# Altium schematic sheet BatteryCharger.SchDoc
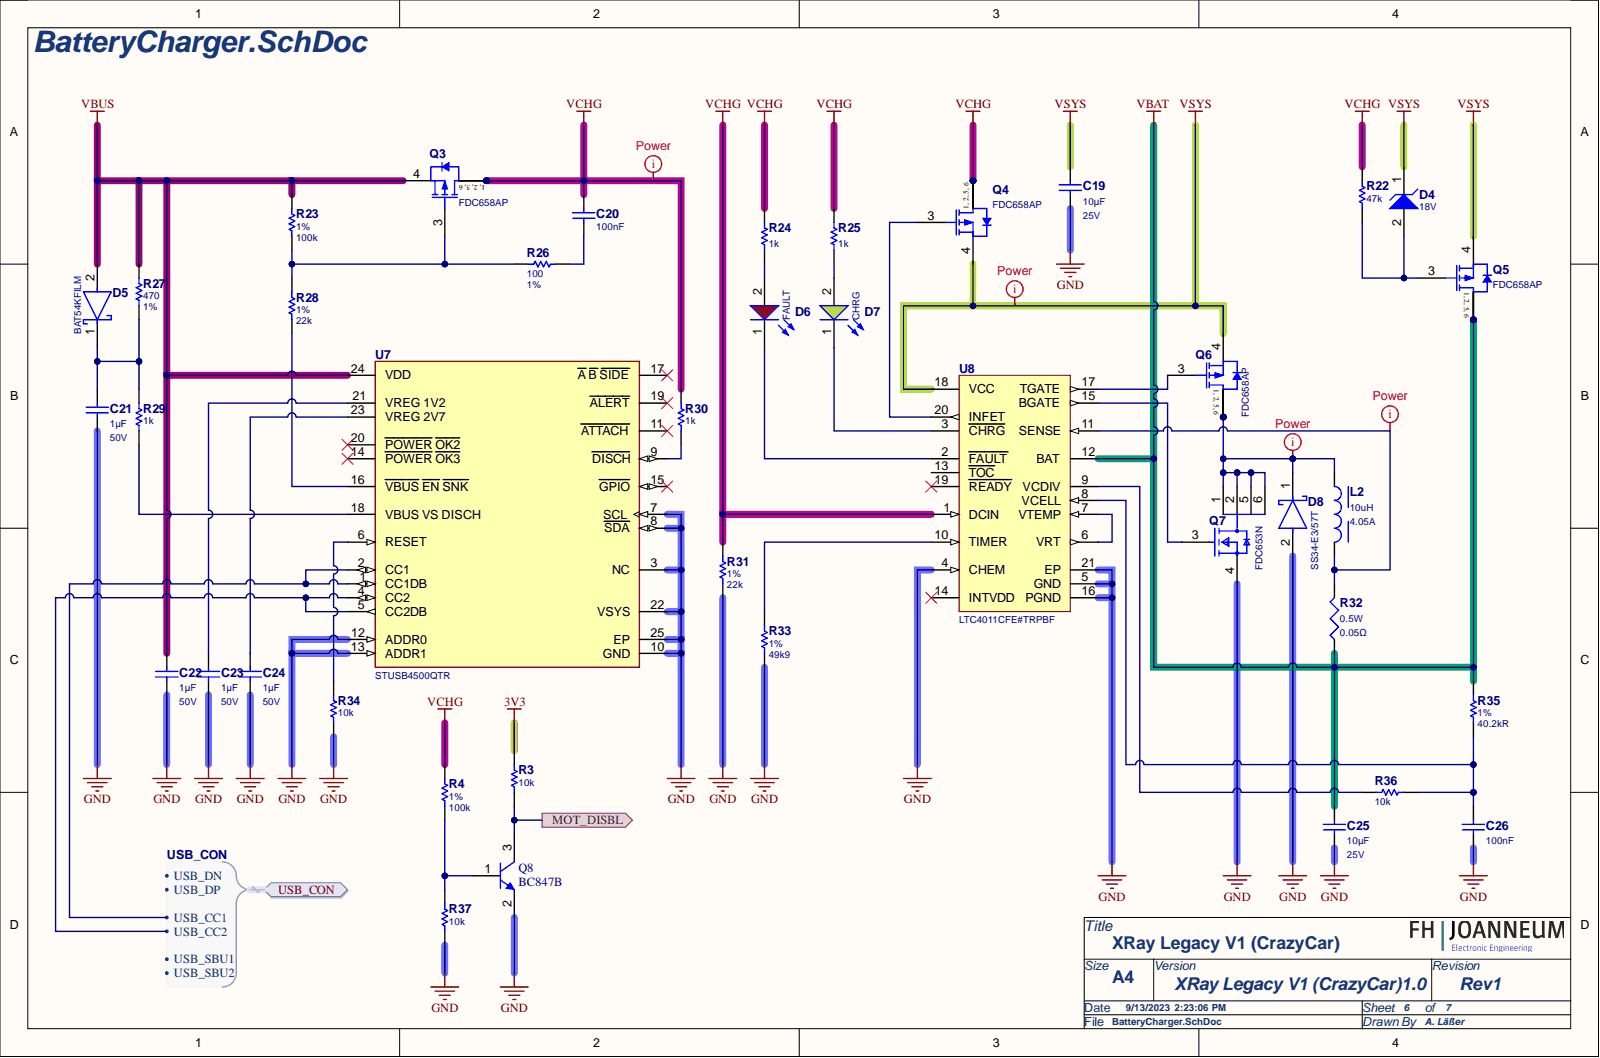
[diagram p0: BatteryCharger.SchDoc]

## Parts
C19  10µF  Rated Voltage (DC): 25V  [WCAP-CSGP_1206_L3.2W1.6H1.6]
C20  100nF  [WCAP-CSGP_0805_L2W1.25H0.8_Reflow]
C21  1µF  Rated Voltage (DC): 50V  [WCAP-CSGP_0805_L2W1.25H1.25]
C22  1µF  Rated Voltage (DC): 50V  [WCAP-CSGP_0805_L2W1.25H1.25]
C23  1µF  Rated Voltage (DC): 50V  [WCAP-CSGP_0805_L2W1.25H1.25]
C24  1µF  Rated Voltage (DC): 50V  [WCAP-CSGP_0805_L2W1.25H1.25]
C25  10µF  Rated Voltage (DC): 25V  [WCAP-CSGP_1206_L3.2W1.6H1.6]
C26  100nF  [WCAP-CSGP_0805_L2W1.25H0.8_Reflow]
D4  VZ Typ (V): 18V  [ONSC-SOD-123-2-425-04_V]  (hidden comment: MMSZ5248BT1G)
D5  BAT54KFILM  [FP-SOD-523-MFG]
D6  FAULT  [WL-SMCC_0603_L1.6W0.8H0.2]
D7  CHRG  [WL-SMCC_0603_L1.6W0.8H0.2]
D8  SS34-E3/57T  [FP-95402-MFG]
L2  10uH, 4.05A  Inductance: 10uH  Performance Rated Current: 4.05A  [WE-LHMI_7050]
Q3  FDC658AP  [FAIR-SOT23-6_V]
Q4  FDC658AP  [FAIR-SOT23-6_V]
Q5  FDC658AP  [FAIR-SOT23-6_V]
Q6  FDC658AP  [FAIR-SOT23-6_V]
Q7  FDC653N  [FP-419BL-MFG]
Q8  BC847B  [INF-SOT23_M]
R3  Resistance: 10k  [RESC2013X60X35ML10T20]  (hidden comment: RC0805FR-0710KL)
R4  Resistance: 100k  Tolerance: 1%  [RESC2013X60X35ML10T20]  (hidden comment: RC0805FR-13100KL)
R22  Resistance: 47k  [RESC2013X60X35NL10T20]  (hidden comment: RC0805FR-0747KL)
R23  Resistance: 100k  Tolerance: 1%  [RESC2013X60X35ML10T20]  (hidden comment: RC0805FR-13100KL)
R24  Resistance: 1k  [RESC2013X60X35ML10T20]  (hidden comment: RC0805FR-071KL)
R25  Resistance: 1k  [RESC2013X60X35ML10T20]  (hidden comment: RC0805FR-071KL)
R26  Tolerance: 1%  [RESC2013X60X35ML10T20]  (hidden comment: RC0805FR-07100RL)
R27  Tolerance: 1%  [RESC2013X60X35ML10T20]  (hidden comment: RC0805FR-07470RL)
R28  Resistance: 22k  Tolerance: 1%  [RESC2013X60X35ML10T20]  (hidden comment: RC0805FR-1022KL)
R29  Resistance: 1k  [RESC2013X60X35ML10T20]  (hidden comment: RC0805FR-071KL)
R30  Resistance: 1k  [RESC2013X60X35ML10T20]  (hidden comment: RC0805FR-071KL)
R31  Resistance: 22k  Tolerance: 1%  [RESC2013X60X35ML10T20]  (hidden comment: RC0805FR-1022KL)
R32  0.05Ω  Rated Power: 0.5W  [WRIS-KSKE_1206_L3.1W1.6H0.6]
R33  Resistance: 49k9  Tolerance: 1%  [RESC2013X60X35LL10T20]  (hidden comment: RC0805FR-1349K9L)
R34  Resistance: 10k  [RESC2013X60X35ML10T20]  (hidden comment: RC0805FR-0710KL)
R35  Resistance: 40.2kR  Tolerance: 1%  [RESC2013X60X35NL10T20]  (hidden comment: RC0805FR-07402KL)
R36  Resistance: 10k  [RESC2013X60X35ML10T20]  (hidden comment: RC0805FR-0710KL)
R37  Resistance: 10k  [RESC2013X60X35ML10T20]  (hidden comment: RC0805FR-0710KL)
U7  STUSB4500QTR  [FP-QFN-24-EP_4x4-MFG]
U8  LTC4011CFE#TRPBF  [LT-FE-20-EPV-CB_M]

## Ports
MOT_DISBL, USB_CON, USB_CON.USB_CC1, USB_CON.USB_CC2, USB_CON.USB_DN, USB_CON.USB_DP, USB_CON.USB_SBU1, USB_CON.USB_SBU2

## Nets
3V3: R3.1
GND: C19.2 C21.2 C22.2 C23.2 C24.2 C25.2 C26.1 D8.2 Q7.4 Q8.2 R31.2 R33.2 R34.1 R37.2 U7.3(NC) U7.7(SCL) U7.8(SDA) U7.10(GND) U7.12(ADDR0) U7.13(ADDR1) U7.22(VSYS) U7.25(EP) U8.4(CHEM) U8.5(GND) U8.16(PGND) U8.21(EP)
VBAT: C25.1 Q5.1 Q5.2 Q5.5 Q5.6 R32.1 R35.2 U8.12(BAT)
VBUS: C22.1 D5.2 Q3.4 R23.1 R27.1 U7.24(VDD)
VCHG: C20.2 Q3.1 Q3.2 Q3.5 Q3.6 Q4.1 Q4.2 Q4.5 Q4.6 R4.1 R22.1 R24.1 R25.1 R30.2 R31.1 U8.1(DCIN)
VSYS: C19.1 D4.1 Q4.4 Q5.4 Q6.4 U8.18(VCC)
MOT_DISBL: Q8.3 R3.2 ; port MOT_DISBL
NetC20_1: C20.1 R26.2
NetC21_1: C21.1 D5.1 R27.2 R29.2
NetC23_1: C23.1 U7.21(VREG_1V2)
NetC24_1: C24.1 U7.23(VREG_2V7)
NetC26_2: C26.2 R35.1 R36.1 U8.8(VCELL)
NetD4_2: D4.2 Q5.3 R22.2
NetD6_1: D6.1 U8.2(FAULT)
NetD6_2: D6.2 R24.2
NetD7_1: D7.1 U8.3(CHRG)
NetD7_2: D7.2 R25.2
NetD8_1: D8.1 L2.1 Q6.1 Q6.2 Q6.5 Q6.6 Q7.1 Q7.2 Q7.5 Q7.6
NetL2_2: L2.2 R32.2 U8.11(SENSE)
NetQ3_3: Q3.3 R23.2 R26.1 R28.1
NetQ4_3: Q4.3 U8.20(INFET)
NetQ6_3: Q6.3 U8.17(TGATE)
NetQ7_3: Q7.3 U8.15(BGATE)
NetQ8_1: Q8.1 R4.2 R37.1
NetR28_2: R28.2 U7.16(VBUS_EN_SNK)
NetR29_1: R29.1 U7.18(VBUS_VS_DISCH)
NetR30_1: R30.1 U7.9(DISCH)
NetR33_1: R33.1 U8.10(TIMER)
NetR34_2: R34.2 U7.6(RESET)
NetR36_2: R36.2 U8.9(VCDIV)
NetU7_1: U7.1(CC1DB) U7.2(CC1) ; port USB_CON
NetU7_4: U7.4(CC2) U7.5(CC2DB) ; port USB_CON
NetU8_6: U8.6(VRT) U8.7(VTEMP)
N00001: ; port USB_CON
N00002: ; port USB_CON
N00003: ; port USB_CON
N00004: ; port USB_CON

Not connected: U7 11(ATTACH) 14(POWER_OK3) 15(GPIO) 17(A_B_SIDE) 19(ALERT) 20(POWER_OK2); U8 13(TOC) 14(INTVDD) 19(READY)

## Library data of the parts
C19 C25 = 885012108021: WCAP-CSGP Multilayer Ceramic Chip Capacitor, General Purpose, size 1206, X5R, 10µF, 25VDC; Manufacturer: Wurth Elektronik; Capacitance Tolerance: +/-20%; Case/Size Code: 1206; Dissipation Factor: 10%; Insulation Resistance: 0.01GΩ; Technical Reference: X5R1206106M025DFCT10000; Capacitance: 10µF; Category: Multilayer Ceramic Chip Capacitors; Ceramic Type: X5R; Component Kind: Standard; ComponentLink1Description: Website Link; ComponentLink1URL: https://www.we-online.com/redexpert/article/885012108021?ad; ComponentLink2Description: Datasheet Link; ComponentLink2URL: https://www.we-online.com/redexpert/spec/885012108021?ad; Height: 1.6mm; Length: 3.2mm; Library Name: Altium_WCAP-CSGP (rev22e).IntLib; Manufacturer Part Number: 885012108021; Match Code: WCAP-CSGP; Mount: Surface Mount; Operating Temperature Max: 85°C; Operating Temperature Min: -55°C; Packaging: Tape and Reel; Width: 1.6mm
C20 C26 = 885012207098: WCAP-CSGP Multilayer Ceramic Chip Capacitor, General Purpose, size 0805, X7R, 100nF, 50VDC; Manufacturer: Wurth Elektronik; Capacitance Tolerance: +/-10%; Case/Size Code: 0805; Dissipation Factor: 2.5%; Insulation Resistance: 5GΩ; Rated Voltage (DC): 50V; Technical Reference: X7R0805104K050DFCT10000; Capacitance: 100nF; Category: Multilayer Ceramic Chip Capacitors; Ceramic Type: X7R; Component Kind: Standard; ComponentLink1Description: Website Link; ComponentLink1URL: https://www.we-online.com/redexpert/article/885012207098?ad; ComponentLink2Description: Datasheet Link; ComponentLink2URL: https://www.we-online.com/redexpert/spec/885012207098?ad; Height: 0.8mm; Length: 2mm; Library Name: Altium_WCAP-CSGP (rev22e).IntLib; Manufacturer Part Number: 885012207098; Match Code: WCAP-CSGP; Mount: Surface Mount; Operating Temperature Max: 125°C; Operating Temperature Min: -55°C; Packaging: Tape and Reel; Width: 1.25mm
C21-C24 = 885012207103: WCAP-CSGP Multilayer Ceramic Chip Capacitor, General Purpose, size 0805, X7R, 1µF, 50VDC; Manufacturer: Wurth Elektronik; Capacitance Tolerance: +/-10%; Case/Size Code: 0805; Dissipation Factor: 10%; Insulation Resistance: 0.1GΩ; Technical Reference: X7R0805105K050DFCT10000; Capacitance: 1µF; Category: Multilayer Ceramic Chip Capacitors; Ceramic Type: X7R; Component Kind: Standard; ComponentLink1Description: Website Link; ComponentLink1URL: https://www.we-online.com/redexpert/article/885012207103?ad; ComponentLink2Description: Datasheet Link; ComponentLink2URL: https://www.we-online.com/redexpert/spec/885012207103?ad; Height: 1.25mm; Length: 2mm; Library Name: Altium_WCAP-CSGP (rev22e).IntLib; Manufacturer Part Number: 885012207103; Match Code: WCAP-CSGP; Mount: Surface Mount; Operating Temperature Max: 125°C; Operating Temperature Min: -55°C; Packaging: Tape and Reel; Width: 1.25mm
D4 = CMP-1060-00505-1: Zener Voltage Regulator, 500 mW, 2-Pin SOD-123, Pb-Free, Tape and Reel; Manufacturer: On Semiconductor; P Max (W): 0.5; Component Kind: Standard; ComponentLink1Description: Manufacturer URL; ComponentLink1URL: http://www.onsemi.com/; ComponentLink2Description: Datasheet; ComponentLink2URL: http://www.onsemi.com/pub_link/Collateral/MMSZ5221BT1-D.PDF; ComponentLink3Description: Package Specification; ComponentLink3URL: http://www.onsemi.com/pub_link/Collateral/425-04.PDF; DatasheetVersion: Rev. 12; Library Name: Altium Content Vault; Mounting Technology: Surface Mount; PackageDescription: 2-Pin SOD, Body 1.6 x 2.69 mm; PackageReference: SOD-123-2-425-04; PackageVersion: Rev. G, 10/2009; Packing: Tape and Reel; PartNumber: MMSZ5248BT1G; RoHS: Pb-Free
D5 = CMP-12187-000026-1: DIODE SCHOTTKY 40V 300MA SOD523; Manufacturer: STMicroelectronics; Capacitance: 7pF; Max Junction Temp: +150°C; Max Surge Forward Current: 1.25A; Peak Reverse Current: 1uA; Value: 7pF; Automotive: No; Category: Diode; Component Kind: Standard; ComponentLink2Description: Datasheet; ComponentLink2URL: https://www.st.com/content/ccc/resource/technical/document/datasheet/07/50/54/f2/0d/ba/4a/79/CD00001322.pdf/files/CD00001322.pdf/jcr:content/translations/en.CD00001322.pdf; Device Class L1: Discrete Semiconductors; Device Class L2: Diodes; Device Class L3: Schottky Diodes; Digikey Description: DIODE SCHOTTKY 40V 300MA SOD523; Forward Current: 300mA; Height: 0.7mm; Lead Free: Yes; Library Name: Altium Content Vault; Manufacturer Part Number: BAT54KFILM; Max Repetitive Reverse Voltage: 40V; Mouser Description: Schottky Diodes & Rectifiers schottky diode; Octopart: https://octopart.com/bat54kfilm-stmicroelectronics-39618022; Package: SOD-523; RoHS: TRUE; Temperature: -40°C to +150°C; Temperature Range High: +150°C; Temperature Range Low: -40°C
D6 = 150060RS73220: WL-SMCC Mono-color Chip LED Compact, size 0603, Red, 2V, 120°; Manufacturer: Wurth Elektronik; Case/Size Code: 0603; Chip Technology: AlInGaP; Dominant Wavelength: 624nm; Luminous Intensity: 90mcd; Peak Wavelength: 632nm; Category: Signal LEDs; Component Kind: Standard; ComponentLink1Description: Website Link; ComponentLink1URL: https://www.we-online.com/redexpert/article/150060RS73220?ad; ComponentLink2Description: Datasheet Link; ComponentLink2URL: https://www.we-online.com/redexpert/spec/150060RS73220?ad; Emitting Color: Red; Forward Voltage: 2V; Height: 0.2mm; Length: 1.6mm; Library Name: Altium_WL-SMCC (rev22b).IntLib; Manufacturer Part Number: 150060RS73220; Match Code: WL-SMCC; Mount: Surface Mount; Operating Temperature Max: 85°C; Operating Temperature Min: -40°C; Packaging: Tape and Reel; Simulation: 0603_150060RS73220; Viewing Angle: 120°; Width: 0.8mm
D7 = 150060YS73220: WL-SMCC Mono-color Chip LED Compact, size 0603, Yellow, 2V, 120°; Manufacturer: Wurth Elektronik; Case/Size Code: 0603; Chip Technology: AlInGaP; Dominant Wavelength: 589nm; Luminous Intensity: 100mcd; Peak Wavelength: 591nm; Category: Signal LEDs; Component Kind: Standard; ComponentLink1Description: Website Link; ComponentLink1URL: https://www.we-online.com/redexpert/article/150060YS73220?ad; ComponentLink2Description: Datasheet Link; ComponentLink2URL: https://www.we-online.com/redexpert/spec/150060YS73220?ad; Emitting Color: Yellow; Forward Voltage: 2V; Height: 0.2mm; Length: 1.6mm; Library Name: Altium_WL-SMCC (rev22b).IntLib; Manufacturer Part Number: 150060YS73220; Match Code: WL-SMCC; Mount: Surface Mount; Operating Temperature Max: 85°C; Operating Temperature Min: -40°C; Packaging: Tape and Reel; Simulation: 0603_150060YS73220; Viewing Angle: 120°; Width: 0.8mm
D8 = CMP-02539-000012-1: DIODE SCHOTTKY 40V 3A DO214AB; Manufacturer: Vishay; Max Junction Temp: +150°C; Max Surge Forward Current: 100A; Peak Reverse Current: 0.5mA; Standoff Height: 0mm; Automotive: No; Category: Diode; Component Kind: Standard; ComponentLink2Description: Datasheet; ComponentLink2URL: http://www.vishay.com/docs/88751/ss32.pdf; Device Class L1: Discrete Semiconductors; Device Class L2: Diodes; Device Class L3: Schottky Diodes; Digikey Description: DIODE SCHOTTKY 40V 3A DO214AB; Footprint Url: http://www.vishay.com/docs/95402/smc.pdf; Forward Current: 3A; Height: 2.62mm; Lead Free: Yes; Library Name: Altium Content Vault; Manufacturer Part Number: SS34-E3/57T; Max Repetitive Reverse Voltage: 40V; Mouser Description: Schottky Diodes & Rectifiers 3.0 Amp 40 Volt; Octopart: https://octopart.com/ss34-e3%2F57t-vishay-39552907; Package: 95402; RoHS: TRUE; Temperature: -55°C to +150°C; Temperature Range High: +150°C; Temperature Range Low: -55°C
L2 = 74437349100: WE-LHMI SMT Power Inductor, size 7050, 10uH, 4.05A, 69mOhm; Manufacturer: Wurth Elektronik; Case/Size Code: 7050; DC Resistance: 65mOhm; Saturation Current @ 10%: 5.6A; Saturation Current @ 30%: 10.5A; Self Resonant Frequency: 12MHz; Category: Power Inductors; Component Kind: Standard; ComponentLink1Description: Website Link; ComponentLink1URL: https://www.we-online.com/redexpert/article/74437349100?ad; ComponentLink2Description: Datasheet Link; ComponentLink2URL: https://www.we-online.com/redexpert/spec/74437349100?ad; DC Resistance Max: 69mOhm; Height: 4.8mm; Length: 6.6mm; Library Name: WE-LHMI (rev23a).IntLib; Manufacturer Part Number: 74437349100; Match Code: WE-LHMI; Mount: Surface Mount; Operating Temperature Max: 125°C; Operating Temperature Min: -40°C; Packaging: Tape and Reel; Simulation: 7050_74437349100_10u; Width: 6.6mm
Q3-Q6 = CMP-2000-06244-1: Single P-Channel Logic Level PowerTrench(R) MOSFET, -30 V, -4 A, -55 to 150 degC, 6-Pin SOT23, RoHS, Tape and Reel; Manufacturer: Fairchild Semiconductor; Drain-Source On Resistance-Max: 50mO; Drain-to-Source Voltage [Vdss]: 30V; Package Version: Rev. 5, 05/2015; Qg Gate Charge: 8.1nC; Rated Power Dissipation: 0.8W; Channel Type: P-Channel; Component Kind: Standard; Datasheet URL: https://datasheet.ciiva.com/15137/getdatasheetpartid-92299-15137737.pdf; Datasheet Version: Rev. B1, 11/2011; Library Name: Altium Content Vault; Manufacturer URL: https://www.fairchildsemi.com/; Mounting Technology: SurfaceMount; Package Description: 6-Pin Small Outline Transistor, Body 2.9 x 1.6 mm, Pitch 0.95 mm; Package Reference: SOT23-6; Package URL: https://www.fairchildsemi.com/package-drawings/MA/MA06A.pdf
Q7 = CMP-07173-000050-1: MOSFET N-CH 30V 5A SSOT-6; Manufacturer: ON Semiconductor; Depletion Mode: False; Drain To Source Resistance: 0.027Ω; Gate Charge At Vgs: 12nC @ 10V; Gate To Source Voltage: 20V; Input Capacitace At Vds: 350pF @ 15V; Max Forward Diode Voltage: 1.2V; Max Junction Temp: +150°C; Number Of Channels: 1; Power Dissipation: 1.6W; Pulse Drain Current: 15A; Rthja Max: 78°C/W; Standoff Height: 0mm; Threshold Vgs Max: 2V; Threshold Vgs Min: 1V; Transconductance: 6.2S; Turn Off Delay Time: 13ns; Turn On Delay Time: 7.5ns; Typ Forward Diode Voltage: 0.75V; Automotive: No; Category: Trans; Component Kind: Standard; ComponentLink2Description: Datasheet; ComponentLink2URL: https://www.onsemi.com/pub/Collateral/FDC653N-D.pdf; Continuous Drain Current: 5A; Device Class L1: Discrete Semiconductors; Device Class L2: Transistors; Device Class L3: MOSFETs; Digikey Description: MOSFET N-CH 30V 5A SSOT-6; Drain To Source Breakdown Voltage: 30V; Drain To Source Voltage: 30V; Footprint Url: https://www.onsemi.com/pub/Collateral/419BL.PDF; Height: 1.1mm; Ipc Land Pattern Name: SOT95P280X100-6; Lead Free: Yes; Library Name: Altium Content Vault; Manufacturer Part Number: FDC653N; Mouser Description: Supplied as a Tape, FDC653N N-Channel MOSFET, 5 A, 30 V, 6-Pin SOT-23 ON Semiconductor; Number Of N Channels: 1; Octopart: https://octopart.com/fdc653n-on+semiconductor-84325763; Package: 419BL; RoHS: TRUE; Temperature: -55°C to +150°C; Temperature Range High: +150°C; Temperature Range Low: -55°C
Q8 = CMP-1177-00042-1: NPN Silicon AF Transistor, 45 V VCEO, 100 mA IC, SOT23, Reel, Green; Manufacturer: Infineon; fT (typ): 250Â MHz; Green: TRUE; IBM (max): 200Â mA; IC (max): 100Â mA; ICBO (max): 15Â nA; Ptot (max): 330Â mW; VCBO (max): 50Â V; VCEO (max): 45Â V; VEBO (max): 6Â V; Component Kind: Standard; ComponentLink1Description: Manufacturer URL; ComponentLink1URL: http://www.infineon.com/; ComponentLink2Description: Datasheet; ComponentLink2URL: http://www.infineon.com/dgdl/bc847series_bc848series_bc849series_bc850series.pdf?folderId=db3a304314dca389011541d30fa21656&fileId=db3a304314dca389011541d4630a1657; ComponentLink3Description: Package Specification; ComponentLink3URL: http://www.infineon.com/dgdl/SOT23-Package_Overview.pdf?folderId=db3a30431936bc4b0119532290053c66&fileId=db3a30431936bc4b0119533468123c85; ICM (max): 200Â mA; Library Name: Altium Content Vault; Mounting Technology: Surface Mount; PackageDescription: 3-Pin Small Outline Transistor Package, 1.3 x 2.9 mm Body, 0.95 mm Pitch; PackageReference: SOT23; PackageVersion: Apr-08; Packing: Reel; PartNumber: BC847B; Polarity: NPN
R3 R34 R36 R37 = CMP-1659-00013-4: Chip Resistor, 10 KOhm, +/- 1%, 0.125 W, -55 to 155 degC, 0805 (2012 Metric), RoHS, Tape and Reel; Manufacturer: Yageo; Additional Feature: LASER TRIMMABLE; Package Height: 0.5mm; Package Length: 2mm; Package Width: 1.25mm; Rated Power Dissipation (P): 125mW; Rated Temperature: 70°C; Temperature Coefficient: 100ppm/°C; Voltage Rating: 150 V; Working Voltage: 150V; Category: Chip SMD Resistors; Component Kind: Standard; ComponentLink1Description: Manufacturer URL; ComponentLink1URL: http://www.yageo.com/; ComponentLink2Description: Datasheet; ComponentLink2URL: http://www.yageo.com/documents/recent/PYu-RC_Group_51_RoHS_L_04.pdf; ComponentLink3Description: https://datasheet.ciiva.com:443/pdfs/VipMasterIC/IC/YAGO/YAGO-S-A0008145938/YAGO-S-A0008145938-1.pdf?src-supplier=IHS+Markit; ComponentLink3URL: https://datasheet.ciiva.com:443/pdfs/VipMasterIC/IC/YAGO/YAGO-S-A0008145938/YAGO-S-A0008145938-1.pdf?src-supplier=IHS+Markit; ComponentLink4Description: https://datasheet.ciiva.com/11808/0900766b8106beab-11808151.pdf?src-supplier=RSComponents; ComponentLink4URL: https://datasheet.ciiva.com/11808/0900766b8106beab-11808151.pdf?src-supplier=RSComponents; ComponentLink5Description: https://datasheet.ciiva.com/46447/444164-46447563.pdf?src-supplier=Element14; ComponentLink5URL: https://datasheet.ciiva.com/46447/444164-46447563.pdf?src-supplier=Element14; ComponentLink6Description: https://datasheet.ciiva.com/2456/pyu-r-int-thick-7-2456973.pdf?src-supplier=Digi-Key; ComponentLink6URL: https://datasheet.ciiva.com/2456/pyu-r-int-thick-7-2456973.pdf?src-supplier=Digi-Key; ComponentLink7Description: https://datasheet.ciiva.com/2456/pyu-r-int-thick-7-2456974.pdf?src-supplier=Digi-Key; ComponentLink7URL: https://datasheet.ciiva.com/2456/pyu-r-int-thick-7-2456974.pdf?src-supplier=Digi-Key; ComponentLink8Description: https://datasheet.ciiva.com/2456/pyu-r-int-thick-7-2456972.pdf?src-supplier=Digi-Key; ComponentLink8URL: https://datasheet.ciiva.com/2456/pyu-r-int-thick-7-2456972.pdf?src-supplier=Digi-Key; ComponentLink9Description: https://datasheet.ciiva.com/12669/rc0805-12669688.pdf?src-supplier=Mouser; ComponentLink9URL: https://datasheet.ciiva.com/12669/rc0805-12669688.pdf?src-supplier=Mouser; ComponentLink10Description: https://datasheet.ciiva.com/16747/rc0805-16747087.pdf?src-supplier=Future+Electronics; ComponentLink10URL: https://datasheet.ciiva.com/16747/rc0805-16747087.pdf?src-supplier=Future+Electronics; Construction: Chip; DatasheetVersion: Rev. 4, 02/2016; Height: 0.5 mm; JESD-609 Code: e3; Length: 2 mm; Library Name: Altium Content Vault; Manufacturer Part Number: RC0805FR-0710KL; Max Operating Temperature: 155 degC; Min Operating Temperature: -55 degC; Mount: Surface Mount; Mounting Technology: Surface Mount; Number of Pins: 2; Package Quantity: 5000; Package Shape: Rectangular; Package Style: SMTMeter; PackageDescription: 2-Pin Surface Mounted Device, Body 2 x 1.25 mm; PackageReference: 0805; Packaging: Tape and Reel; Pins: 2; Pricing 1: 5000=0.00700 (USD); REACH SVHC: No SVHC; Resistor Type: FIXED RESISTOR; RoHS: Yes; RoHS Compliant: Yes; RoHSCompliant: true; Size Code: 0805; Stock 1: 435000; Supplier 1: RSComponents; Supplier Part Number 1: 6185163; Technology: METAL GLAZE/THICK FILM; Terminal Finish: Nickel; Termination: Wraparound; Tolerance: 1%
R4 = CMP-2001-01326-1: Manufacturer: Yageo; Additional Feature: LASER TRIMMABLE; Package Height: 0.5mm; Package Length: 2mm; Package Width: 1.25mm; Rated Power Dissipation (P): 125mW; Rated Temperature: 70°C; Temperature Coefficient: 100ppm/°C; Working Voltage: 150V; Category: Chip SMD Resistors; Component Kind: Standard; ComponentLink1Description: https://datasheet.ciiva.com:443/pdfs/VipMasterIC/IC/YAGO/YAGO-S-A0008145938/YAGO-S-A0008145938-1.pdf?src-supplier=IHS+Markit; ComponentLink1URL: https://datasheet.ciiva.com:443/pdfs/VipMasterIC/IC/YAGO/YAGO-S-A0008145938/YAGO-S-A0008145938-1.pdf?src-supplier=IHS+Markit; ComponentLink2Description: https://datasheet.ciiva.com/5558/rc0805-5558047.pdf?src-supplier=Mouser; ComponentLink2URL: https://datasheet.ciiva.com/5558/rc0805-5558047.pdf?src-supplier=Mouser; Construction: Chip; JESD-609 Code: e3; Library Name: Altium Content Vault; Manufacturer Part Number: RC0805FR-13100KL; Max Operating Temperature: 155°C; Min Operating Temperature: -55°C; Mount: Surface Mount; Number of Pins: 2; Package Shape: Rectangular; Package Style: SMTMeter; Packaging: Tape and Reel; Part Number: RC0805FR-13100KL; Pricing 1: 20000=0.0022, 80000=0.002, 300000=0.002, 800000=0.0019, 2000000=0.0018 (USD); Reach SVHC Compliant: Yes; Resistor Type: FIXED RESISTOR; RoHS: Yes; RoHS Compliant: Yes; Size Code: 0805; Stock 1: 3260000; Supplier 1: Future Electronics; Supplier Part Number 1: 1501832; Technology: METAL GLAZE/THICK FILM; Terminal Finish: Nickel; Termination: Wraparound
R22 = CMP-1659-00011-4: Chip Resistor, 47 KOhm, +/- 1%, 0.125 W, -55 to 155 degC, 0805 (2012 Metric), RoHS, Tape and Reel; Manufacturer: Yageo; Additional Feature: LASER TRIMMABLE; Package Height: 0.5mm; Package Length: 2mm; Package Width: 1.25mm; Rated Power Dissipation (P): 125mW; Rated Temperature: 70°C; Temperature Coefficient: 100ppm/°C; Voltage Rating: 150 V; Working Voltage: 150V; Category: Chip SMD Resistors; Component Kind: Standard; ComponentLink1Description: Manufacturer URL; ComponentLink1URL: http://www.yageo.com/; ComponentLink2Description: Datasheet; ComponentLink2URL: http://www.yageo.com/documents/recent/PYu-RC_Group_51_RoHS_L_04.pdf; ComponentLink3Description: https://datasheet.ciiva.com:443/pdfs/VipMasterIC/IC/YAGO/YAGO-S-A0008145938/YAGO-S-A0008145938-1.pdf?src-supplier=IHS+Markit; ComponentLink3URL: https://datasheet.ciiva.com:443/pdfs/VipMasterIC/IC/YAGO/YAGO-S-A0008145938/YAGO-S-A0008145938-1.pdf?src-supplier=IHS+Markit; ComponentLink4Description: https://datasheet.ciiva.com/7941/1686128-7941799.pdf?src-supplier=Element14; ComponentLink4URL: https://datasheet.ciiva.com/7941/1686128-7941799.pdf?src-supplier=Element14; ComponentLink5Description: https://datasheet.ciiva.com/46447/444164-46447581.pdf?src-supplier=Element14; ComponentLink5URL: https://datasheet.ciiva.com/46447/444164-46447581.pdf?src-supplier=Element14; ComponentLink6Description: https://datasheet.ciiva.com/7941/444164-7941800.pdf?src-supplier=Element14; ComponentLink6URL: https://datasheet.ciiva.com/7941/444164-7941800.pdf?src-supplier=Element14; ComponentLink7Description: https://datasheet.ciiva.com/16742/rc0805-16742265.pdf?src-supplier=Future+Electronics; ComponentLink7URL: https://datasheet.ciiva.com/16742/rc0805-16742265.pdf?src-supplier=Future+Electronics; ComponentLink8Description: https://datasheet.ciiva.com/7941/444164-7941801.pdf?src-supplier=Element14; ComponentLink8URL: https://datasheet.ciiva.com/7941/444164-7941801.pdf?src-supplier=Element14; Construction: Chip; DatasheetVersion: Rev. 4, 02/2016; Height: 0.5 mm; JESD-609 Code: e3; Length: 2 mm; Library Name: Altium Content Vault; Manufacturer Part Number: RC0805FR-0747KL; Max Operating Temperature: 155 degC; Min Operating Temperature: -55 degC; Mount: Surface Mount; Mounting Technology: Surface Mount; Number of Pins: 2; Package Quantity: 200; Package Shape: Rectangular; Package Style: SMTMeter; PackageDescription: 2-Pin Surface Mounted Device, Body 2 x 1.25 mm; PackageReference: 0805; Packaging: Tape and Reel; Pins: 2; Pricing 1: 5000=0.0027, 25000=0.0025, 125000=0.0024, 500000=0.0023, 1250000=0.0021 (USD); REACH SVHC: No SVHC; Reach SVHC Compliant: Yes; Resistor Type: FIXED RESISTOR; RoHS: Yes; RoHS Compliant: Yes; RoHSCompliant: true; Size Code: 0805; Stock 1: 3635000; Supplier 1: Future Electronics; Supplier Part Number 1: 2357531; Technology: METAL GLAZE/THICK FILM; Terminal Finish: Nickel; Termination: Wraparound; Tolerance: 1%
R23 = CMP-2001-01326-1: Fixed Resistor, Metal Glaze/thick Film, 0.125W, 100000ohm, 150V, 1% +/-Tol, 100ppm/Cel, Surface Mount, 0805; Manufacturer: Yageo; Additional Feature: LASER TRIMMABLE; Package Height: 0.5mm; Package Length: 2mm; Package Width: 1.25mm; Rated Power Dissipation (P): 125mW; Rated Temperature: 70°C; Temperature Coefficient: 100ppm/°C; Category: Chip SMD Resistors; Component Kind: Standard; ComponentLink1Description: https://datasheet.ciiva.com:443/pdfs/VipMasterIC/IC/YAGO/YAGO-S-A0008145938/YAGO-S-A0008145938-1.pdf?src-supplier=IHS+Markit; ComponentLink1URL: https://datasheet.ciiva.com:443/pdfs/VipMasterIC/IC/YAGO/YAGO-S-A0008145938/YAGO-S-A0008145938-1.pdf?src-supplier=IHS+Markit; ComponentLink2Description: https://datasheet.ciiva.com/5558/rc0805-5558047.pdf?src-supplier=Mouser; ComponentLink2URL: https://datasheet.ciiva.com/5558/rc0805-5558047.pdf?src-supplier=Mouser; Construction: Chip; JESD-609 Code: e3; Library Name: Altium Content Vault; Manufacturer Part Number: RC0805FR-13100KL; Max Operating Temperature: 155°C; Min Operating Temperature: -55°C; Mount: Surface Mount; Number of Pins: 2; Package Shape: Rectangular; Package Style: SMTMeter; Packaging: Tape and Reel; Part Number: RC0805FR-13100KL; Pricing 1: 20000=0.002, 80000=0.0019, 300000=0.0018, 800000=0.0017, 2000000=0.0016 (USD); Reach SVHC Compliant: Yes; Resistor Type: FIXED RESISTOR; RoHS: Yes; RoHS Compliant: Yes; Size Code: 0805; Stock 1: 3460000; Supplier 1: Future Electronics; Supplier Part Number 1: 1501832; Technology: METAL GLAZE/THICK FILM; Terminal Finish: Nickel; Termination: Wraparound; Working Voltage: 150V
R24 R25 R29 R30 = CMP-2100-03671-1: Chip Resistor, 1 KOhm, +/- 1%, 125 mW, -55 to 155 degC, 0805 (2012 Metric), RoHS, Tape and Reel; Manufacturer: Yageo; Additional Feature: LASER TRIMMABLE; Max Power Dissipation: 125mW; Package Height: 0.5mm; Package Length: 2mm; Package Width: 1.25mm; Rated Temperature: 70°C; Temperature Coefficient: 100ppm/degreesC; Voltage Rating: 150V; Case Code (Imperial): 0805; Case Code (Metric): 2012; Case/Package: 0805; Category: Chip SMD Resistors; Component Kind: Standard; ComponentLink1Description: https://datasheet.ciiva.com:443/pdfs/VipMasterIC/IC/YAGO/YAGO-S-A0008145938/YAGO-S-A0008145938-1.pdf?src-supplier=IHS+Markit; ComponentLink1URL: https://datasheet.ciiva.com:443/pdfs/VipMasterIC/IC/YAGO/YAGO-S-A0008145938/YAGO-S-A0008145938-1.pdf?src-supplier=IHS+Markit; ComponentLink2Description: https://datasheet.ciiva.com/7941/1686128-7941719.pdf?src-supplier=Element14; ComponentLink2URL: https://datasheet.ciiva.com/7941/1686128-7941719.pdf?src-supplier=Element14; ComponentLink3Description: https://datasheet.ciiva.com/46385/444164-46385020.pdf?src-supplier=Element14; ComponentLink3URL: https://datasheet.ciiva.com/46385/444164-46385020.pdf?src-supplier=Element14; ComponentLink4Description: https://datasheet.ciiva.com/2456/pyu-r-int-thick-7-2456976.pdf?src-supplier=Digi-Key; ComponentLink4URL: https://datasheet.ciiva.com/2456/pyu-r-int-thick-7-2456976.pdf?src-supplier=Digi-Key; ComponentLink5Description: https://datasheet.ciiva.com/2456/pyu-r-int-thick-7-2456977.pdf?src-supplier=Digi-Key; ComponentLink5URL: https://datasheet.ciiva.com/2456/pyu-r-int-thick-7-2456977.pdf?src-supplier=Digi-Key; ComponentLink6Description: https://datasheet.ciiva.com/2456/pyu-r-int-thick-7-2456975.pdf?src-supplier=Digi-Key; ComponentLink6URL: https://datasheet.ciiva.com/2456/pyu-r-int-thick-7-2456975.pdf?src-supplier=Digi-Key; ComponentLink7Description: https://datasheet.ciiva.com/16850/rc0805-16850409.pdf?src-supplier=Future+Electronics; ComponentLink7URL: https://datasheet.ciiva.com/16850/rc0805-16850409.pdf?src-supplier=Future+Electronics; Composition: ThickFilm; Construction: Chip; Datasheet URL: http://www.yageo.com/NewPortal/yageodocoutput?fileName=/pdf/R-Chip/PYu-RC_Group_51_RoHS_L_5.pdf; Datasheet Version: Rev. 5, 10/2016; Depth: 1.25mm; Height: 0.024inch; JESD-609 Code: e3; Lead Free: LeadFree; Length: 0.079inch; Library Name: Altium Content Vault; Manufacturer Part Number: RC0805FR-071KL; Manufacturer URL: http://www.yageo.com/; Max Operating Temperature: 155degC; Military Standard: Not; Min Operating Temperature: -55degC; Mount: Surface Mount; Number of Pins: 2; Number of Terminations: 2; Package Description: 2-Pin Surface Mounted Device, Body 2 x 1.25 mm; Package Quantity: 1; Package Reference: 0805; Package Shape: Rectangular; Package Style: SMTMeter; Packaging: TapeandReel; Power Rating: 125mW; Pricing 1: 5000=0.00500 (USD); Rated Power Dissipation (P): 125mW; REACH SVHC: Unknown; Resistor Type: FIXED RESISTOR; RoHS: Yes; RoHS Compliant: Yes; RoHSCompliant: true; Size Code: 0805; Stock 1: 490000; Supplier 1: RSComponents; Supplier Part Number 1: 6183583; Technology: METAL GLAZE/THICK FILM; Terminal Finish: Nickel; Termination: SMD/SMT; Tolerance: 1%; Voltage Rating (DC): 150V; Width: 0.049inch; Working Voltage: 150V
R26 = CMP-2100-03669-1: Chip Resistor, 100 Ohm, +/-1%, 0.125 W, -55 to 155 degC, 0805 (2012 Metric), RoHS, Tape and Reel; Manufacturer: Yageo; Additional Feature: LASER TRIMMABLE; Max Power Dissipation: 125mW; Package Height: 0.5mm; Package Length: 2mm; Package Width: 1.25mm; Rated Temperature: 70°C; Resistance: 100; Temperature Coefficient: 100ppm/degreesC; Voltage Rating: 150V; Case Code (Imperial): 0805; Case Code (Metric): 2012; Case/Package: 0805; Category: Chip SMD Resistors; Component Kind: Standard; ComponentLink1Description: https://datasheet.ciiva.com:443/pdfs/VipMasterIC/IC/YAGO/YAGO-S-A0008145938/YAGO-S-A0008145938-1.pdf?src-supplier=IHS+Markit; ComponentLink1URL: https://datasheet.ciiva.com:443/pdfs/VipMasterIC/IC/YAGO/YAGO-S-A0008145938/YAGO-S-A0008145938-1.pdf?src-supplier=IHS+Markit; ComponentLink2Description: https://datasheet.ciiva.com/7941/1697719-7941680.pdf?src-supplier=Element14; ComponentLink2URL: https://datasheet.ciiva.com/7941/1697719-7941680.pdf?src-supplier=Element14; ComponentLink3Description: https://datasheet.ciiva.com/11808/0900766b8106beab-11808877.pdf?src-supplier=Rs; ComponentLink3URL: https://datasheet.ciiva.com/11808/0900766b8106beab-11808877.pdf?src-supplier=Rs; ComponentLink4Description: https://datasheet.ciiva.com/22747/444164-22747535.pdf?src-supplier=Element14; ComponentLink4URL: https://datasheet.ciiva.com/22747/444164-22747535.pdf?src-supplier=Element14; ComponentLink5Description: https://datasheet.ciiva.com/2456/pyu-r-int-thick-7-2456988.pdf?src-supplier=Digi-Key; ComponentLink5URL: https://datasheet.ciiva.com/2456/pyu-r-int-thick-7-2456988.pdf?src-supplier=Digi-Key; ComponentLink6Description: https://datasheet.ciiva.com/2456/pyu-r-int-thick-7-2456989.pdf?src-supplier=Digi-Key; ComponentLink6URL: https://datasheet.ciiva.com/2456/pyu-r-int-thick-7-2456989.pdf?src-supplier=Digi-Key; ComponentLink7Description: https://datasheet.ciiva.com/2456/pyu-r-int-thick-7-2456987.pdf?src-supplier=Digi-Key; ComponentLink7URL: https://datasheet.ciiva.com/2456/pyu-r-int-thick-7-2456987.pdf?src-supplier=Digi-Key; ComponentLink8Description: https://datasheet.ciiva.com/17082/rc0805-17082697.pdf?src-supplier=Future+Electronics; ComponentLink8URL: https://datasheet.ciiva.com/17082/rc0805-17082697.pdf?src-supplier=Future+Electronics; ComponentLink9Description: https://datasheet.ciiva.com/7941/1686128-7941679.pdf?src-supplier=Element14; ComponentLink9URL: https://datasheet.ciiva.com/7941/1686128-7941679.pdf?src-supplier=Element14; ComponentLink10Description: https://datasheet.ciiva.com/7660/38627-7660797.pdf?src-supplier=Element14; ComponentLink10URL: https://datasheet.ciiva.com/7660/38627-7660797.pdf?src-supplier=Element14; ComponentLink11Description: https://datasheet.ciiva.com/46447/444164-46447562.pdf?src-supplier=Element14; ComponentLink11URL: https://datasheet.ciiva.com/46447/444164-46447562.pdf?src-supplier=Element14; Composition: ThickFilm; Construction: Chip; Datasheet URL: http://www.yageo.com/NewPortal/yageodocoutput?fileName=/pdf/R-Chip/PYu-RC_Group_51_RoHS_L_5.pdf; Datasheet Version: Rev. 5, 10/2016; Depth: 1.25mm; Height: 0.024inch; JESD-609 Code: e3; Lead Free: LeadFree; Lead Pitch: 0.4mm; Length: 2mm; Library Name: Altium Content Vault; Manufacturer Part Number: RC0805FR-07100RL; Manufacturer URL: http://www.yageo.com/; Max Operating Temperature: 155degC; Military Standard: Not; Min Operating Temperature: -55degC; Mount: Surface Mount; Number of Pins: 2; Number of Terminations: 2; Package Description: 2-Pin Surface Mounted Device, Body 2 x 1.25 mm; Package Quantity: 1; Package Reference: 0805; Package Shape: Rectangular; Package Style: SMTMeter; Packaging: TapeandReel; Power Rating: 125mW; Pricing 1: 5000=0.0025, 25000=0.0024, 125000=0.0022, 500000=0.0021, 1250000=0.002 (USD); Rated Power Dissipation (P): 125mW; REACH SVHC: Unknown; Reach SVHC Compliant: Yes; Resistor Type: FIXED RESISTOR; RoHS: Yes; RoHS Compliant: Yes; RoHSCompliant: true; Size Code: 0805; Stock 1: 1765000; Supplier 1: Future Electronics; Supplier Part Number 1: 8111957; Technology: METAL GLAZE/THICK FILM; Terminal Finish: Nickel; Termination: SMD/SMT; Voltage Rating (DC): 150V; Width: 0.049inch; Working Voltage: 150V
R27 = CMP-2000-06961-1: Chip Resistor, 470 Ohm, +/- 1%, 125 mW, -55 to 155 degC, 0805 (2012 Metric), RoHS, Tape and Reel; Manufacturer: Yageo; Additional Feature: LASER TRIMMABLE; Package Height: 0.5mm; Package Length: 2mm; Package Width: 1.25mm; Power Rating: 250mW; Rated Power Dissipation (P): 125mW; Rated Temperature: 70°C; Resistance: 470; Resistor Case Style: 0805[2012Metric]; Resistor Element Type: ThickFilm; SVHC: ToBeAdvised; Temperature Coefficient: ±100ppm/°C; Voltage Rating: 150V; Automotive Qualification Standard: -; Category: Chip SMD Resistors; Component Kind: Standard; ComponentLink1Description: https://datasheet.ciiva.com:443/pdfs/VipMasterIC/IC/YAGO/YAGO-S-A0008145938/YAGO-S-A0008145938-1.pdf?src-supplier=IHS+Markit; ComponentLink1URL: https://datasheet.ciiva.com:443/pdfs/VipMasterIC/IC/YAGO/YAGO-S-A0008145938/YAGO-S-A0008145938-1.pdf?src-supplier=IHS+Markit; ComponentLink2Description: https://datasheet.ciiva.com/46447/444164-46447580.pdf?src-supplier=Element14; ComponentLink2URL: https://datasheet.ciiva.com/46447/444164-46447580.pdf?src-supplier=Element14; ComponentLink3Description: https://datasheet.ciiva.com/2457/pyu-r-int-thick-7-2457058.pdf?src-supplier=Digi-Key; ComponentLink3URL: https://datasheet.ciiva.com/2457/pyu-r-int-thick-7-2457058.pdf?src-supplier=Digi-Key; ComponentLink4Description: https://datasheet.ciiva.com/2457/pyu-r-int-thick-7-2457056.pdf?src-supplier=Digi-Key; ComponentLink4URL: https://datasheet.ciiva.com/2457/pyu-r-int-thick-7-2457056.pdf?src-supplier=Digi-Key; ComponentLink5Description: https://datasheet.ciiva.com/7941/444164-7941798.pdf?src-supplier=Element14; ComponentLink5URL: https://datasheet.ciiva.com/7941/444164-7941798.pdf?src-supplier=Element14; ComponentLink6Description: https://datasheet.ciiva.com/16745/rc0805-16745229.pdf?src-supplier=Future+Electronics; ComponentLink6URL: https://datasheet.ciiva.com/16745/rc0805-16745229.pdf?src-supplier=Future+Electronics; ComponentLink7Description: https://datasheet.ciiva.com/2457/pyu-r-int-thick-7-2457057.pdf?src-supplier=Digi-Key; ComponentLink7URL: https://datasheet.ciiva.com/2457/pyu-r-int-thick-7-2457057.pdf?src-supplier=Digi-Key; ComponentLink8Description: https://datasheet.ciiva.com/7941/444164-7941797.pdf?src-supplier=Element14; ComponentLink8URL: https://datasheet.ciiva.com/7941/444164-7941797.pdf?src-supplier=Element14; Construction: Chip; Datasheet URL: http://www.yageo.com/documents/recent/PYu-RC_Group_51_RoHS_L_7.pdf; Datasheet Version: Rev. 7, 05/2017; JESD-609 Code: e3; Library Name: Altium Content Vault; Manufacturer Part Number: RC0805FR-07470RL; Manufacturer URL: http://www.yageo.com/; Max Operating Temperature: 155°C; Min Operating Temperature: -55°C; Mount: Surface Mount; Mounting Technology: SurfaceMount; MSL: MSL1-Unlimited; Number of Pins: 2; Package Description: 2-Pin Surface Mounted Device, Body 2 x 1.25 mm; Package Reference: 0805; Package Shape: Rectangular; Package Style: SMTMeter; Packaging: Tape&Reel; Pricing 1: 5000=0.0021, 25000=0.002, 125000=0.0019, 500000=0.0018, 1250000=0.0016 (USD); Product Range: -; Reach SVHC Compliant: Yes; Resistance Tolerance: ±1%; Resistor Type: FIXED RESISTOR; RoHS: Yes; RoHS Compliant: Yes; Size Code: 0805; Stock 1: 3640000; Supplier 1: Future Electronics; Supplier Part Number 1: 9919440; Technology: METAL GLAZE/THICK FILM; Terminal Finish: Nickel; Termination: Wraparound; Working Voltage: 150V
R28 R31 = CMP-2001-04525-1: Fixed Resistor, Metal Glaze/thick Film, 0.125W, 22000ohm, 150V, 1% +/-Tol, 100ppm/Cel, Surface Mount, 0805; Manufacturer: Yageo; Additional Feature: LASER TRIMMABLE; Package Height: 0.5mm; Package Length: 2mm; Package Width: 1.25mm; Rated Power Dissipation (P): 125mW; Rated Temperature: 70°C; Temperature Coefficient: 100ppm/°C; Category: Chip SMD Resistors; Component Kind: Standard; ComponentLink1Description: https://datasheet.ciiva.com:443/pdfs/VipMasterIC/IC/YAGO/YAGO-S-A0008145938/YAGO-S-A0008145938-1.pdf?src-supplier=IHS+Markit; ComponentLink1URL: https://datasheet.ciiva.com:443/pdfs/VipMasterIC/IC/YAGO/YAGO-S-A0008145938/YAGO-S-A0008145938-1.pdf?src-supplier=IHS+Markit; ComponentLink2Description: http://www.mouser.com/ds/2/447/Yageo_08112016_RC0805-968729.pdf?src-supplier=Mouser; ComponentLink2URL: http://www.mouser.com/ds/2/447/Yageo_08112016_RC0805-968729.pdf?src-supplier=Mouser; ComponentLink3Description: http://www.yageo.com/documents/recent/PYu-RC_Group_51_RoHS_L_2.pdf?src-supplier=Avnet; ComponentLink3URL: http://www.yageo.com/documents/recent/PYu-RC_Group_51_RoHS_L_2.pdf?src-supplier=Avnet; Construction: Chip; JESD-609 Code: e3; Library Name: Altium Content Vault; Manufacturer Part Number: RC0805FR-1022KL; Max Operating Temperature: 155°C; Min Operating Temperature: -55°C; Mount: Surface Mount; Number of Pins: 2; Package Shape: Rectangular; Package Style: SMTMeter; Packaging: Tape and Reel; Part Number: RC0805FR-1022KL; Pricing 1: 1=0.0914, 10=0.0146, 100=0.0092, 1000=0.007, 2500=0.0055, 5000=0.005, 10000=0.0037, 30000=0.0035, 50000=0.0034, 100000=0.0032 (USD); Reach SVHC Compliant: Yes; Resistor Type: FIXED RESISTOR; RoHS: Yes; RoHS Compliant: Yes; Size Code: 0805; Stock 1: 5081; Supplier 1: Arrow Electronics; Supplier Part Number 1: RC0805FR-1022KL; Technology: METAL GLAZE/THICK FILM; Terminal Finish: Nickel; Termination: Wraparound; Working Voltage: 150V
R32 = 560050332001: WRIS-KSKE Thick Film Resistor, SMT, size 1206, 0.5W, 0.05Ω, +/-1%; Manufacturer: Wurth Elektronik; Case/Size Code: 1206; Rated Current: 3.16A; Technical Reference: KE1206R050F102LAATP0000; Temperature Coefficient of Resistance: 250ppm/°C; Category: Thick Film Resistors; Component Kind: Standard; ComponentLink1Description: Website Link; ComponentLink1URL: https://www.we-online.com/redexpert/article/560050332001?ad; ComponentLink2Description: Datasheet Link; ComponentLink2URL: https://www.we-online.com/redexpert/spec/560050332001?ad; Height: 0.6mm; Length: 3.1mm; Library Name: Altium_WRIS-KSKE (rev22a) (1).IntLib; Manufacturer Part Number: 560050332001; Match Code: WRIS-KSKE; Mount: Surface Mount; Operating Temperature Max: 155°C; Operating Temperature Min: -55°C; Packaging: Tape and Reel; Resistance: 0.05Ω; Resistance Tolerance: +/-1%; Width: 1.6mm
R33 = CMP-2001-01340-1: Fixed Resistor, Metal Glaze/thick Film, 0.125W, 49900ohm, 150V, 1% +/-Tol, 100ppm/Cel, Surface Mount, 0805; Manufacturer: Yageo; Additional Feature: LASER TRIMMABLE; Package Height: 0.5mm; Package Length: 2mm; Package Width: 1.25mm; Rated Power Dissipation (P): 125mW; Rated Temperature: 70°C; Temperature Coefficient: 100ppm/°C; Category: Chip SMD Resistors; Component Kind: Standard; ComponentLink1Description: https://datasheet.ciiva.com:443/pdfs/VipMasterIC/IC/YAGO/YAGO-S-A0008145938/YAGO-S-A0008145938-1.pdf?src-supplier=IHS+Markit; ComponentLink1URL: https://datasheet.ciiva.com:443/pdfs/VipMasterIC/IC/YAGO/YAGO-S-A0008145938/YAGO-S-A0008145938-1.pdf?src-supplier=IHS+Markit; ComponentLink2Description: https://datasheet.ciiva.com/16741/rc0805-16741254.pdf?src-supplier=Future+Electronics; ComponentLink2URL: https://datasheet.ciiva.com/16741/rc0805-16741254.pdf?src-supplier=Future+Electronics; Construction: Chip; JESD-609 Code: e3; Library Name: Altium Content Vault; Manufacturer Part Number: RC0805FR-1349K9L; Max Operating Temperature: 155°C; Min Operating Temperature: -55°C; Mount: Surface Mount; Number of Pins: 2; Package Shape: Rectangular; Package Style: SMTMeter; Packaging: Tape and Reel; Part Number: RC0805FR-1349K9L; Pricing 1: 20000=0.002, 80000=0.0019, 300000=0.0018, 800000=0.0017, 2000000=0.0016 (USD); Reach SVHC Compliant: Yes; Resistor Type: FIXED RESISTOR; RoHS: Yes; RoHS Compliant: Yes; Size Code: 0805; Stock 1: 40000; Supplier 1: Future Electronics; Supplier Part Number 1: 9019817; Technology: METAL GLAZE/THICK FILM; Terminal Finish: Nickel; Termination: Wraparound; Working Voltage: 150V
R35 = CMP-2001-01136-1: Fixed Resistor, Metal Glaze/thick Film, 0.125W, 40200ohm, 150V, 1% +/-Tol, 100ppm/Cel, Surface Mount, 0805; Manufacturer: Yageo; Manufacturer Part Number: RC0805FR-0740K2L; Additional Feature: LASER TRIMMABLE; Package Height: 0.5mm; Package Length: 2mm; Package Width: 1.25mm; Rated Power Dissipation (P): 125mW; Rated Temperature: 70°C; Temperature Coefficient: 100ppm/°C; Category: Chip SMD Resistors; Component Kind: Standard; ComponentLink1Description: https://datasheet.ciiva.com:443/pdfs/VipMasterIC/IC/YAGO/YAGO-S-A0008145938/YAGO-S-A0008145938-1.pdf?src-supplier=IHS+Markit; ComponentLink1URL: https://datasheet.ciiva.com:443/pdfs/VipMasterIC/IC/YAGO/YAGO-S-A0008145938/YAGO-S-A0008145938-1.pdf?src-supplier=IHS+Markit; ComponentLink2Description: https://datasheet.ciiva.com/11808/0900766b8106beab-11808332.pdf?src-supplier=Rs; ComponentLink2URL: https://datasheet.ciiva.com/11808/0900766b8106beab-11808332.pdf?src-supplier=Rs; ComponentLink3Description: https://datasheet.ciiva.com/12656/rc0805-12656719.pdf?src-supplier=Mouser; ComponentLink3URL: https://datasheet.ciiva.com/12656/rc0805-12656719.pdf?src-supplier=Mouser; Construction: Chip; JESD-609 Code: e3; Library Name: Altium Content Vault; Max Operating Temperature: 155°C; Min Operating Temperature: -55°C; Mount: Surface Mount; Number of Pins: 2; Package Shape: Rectangular; Package Style: SMTMeter; Packaging: Tape and Reel; Part Number: RC0805FR-07402KL; Pricing 1: 5000=0.0027, 25000=0.0025, 125000=0.0024, 500000=0.0023, 1250000=0.0021 (USD); Reach SVHC Compliant: Yes; Resistor Type: FIXED RESISTOR; RoHS: Yes; RoHS Compliant: Yes; Size Code: 0805; Stock 1: 350000; Supplier 1: Future Electronics; Supplier Part Number 1: 6759476; Technology: METAL GLAZE/THICK FILM; Terminal Finish: Nickel; Termination: Wraparound; Working Voltage: 150V
U7 = CMP-12113-000003-1: IC USB CONTROLLER I2C 24QFN; Case/Package: QFN; Component Kind: Standard; Interface: I2C; Library Name: Altium Content Vault; Max Operating Temperature: 105°C; Min Operating Temperature: -40°C; Packaging: Tape and Reel
U8 = CMP-0471-00172-1: High Efficiency Standalone Nickel Battery Charger, 4.5 to 34 V Vin, 20-pin SOP (FE-20-EPV-CB), 0 to 85 degC, Pb-Free, Tape and Reel; Manufacturer: Linear Technology; Battery Chemistry: NiCd, NiMH; Float Voltage: Adj; Max Charge Current(A): 4; Number of Cells: 1 to 16; Type of Charger: Switching; Vin Max(V): 34; Vin Min(V): 4.5; Component Kind: Standard; ComponentLink1Description: Manufacturer URL; ComponentLink1URL: http://www.linear.com/; ComponentLink2Description: Datasheet; ComponentLink2URL: http://cds.linear.com/docs/en/datasheet/4011fb.pdf; ComponentLink3Description: Package Specification; ComponentLink3URL: http://cds.linear.com/docs/en/packaging/05081663__J_FE20(CB).pdf; DatasheetVersion: Rev. B; Library Name: Altium Content Vault; Mounting Technology: Surface Mount; PackageDescription: 20-Pin Plastic TSSOP, Body 6.5 x 4.4 mm, Pitch 0.65 mm; PackageReference: FE-20; PackageVersion: Rev. I, 05/2011; Packing: Tape and Reel; PartNumber: LTC4011CFE#TRPBF; RoHS: TRUE
